# Altium schematic sheet DTU_PWR.SchDoc(DTU_PWR)
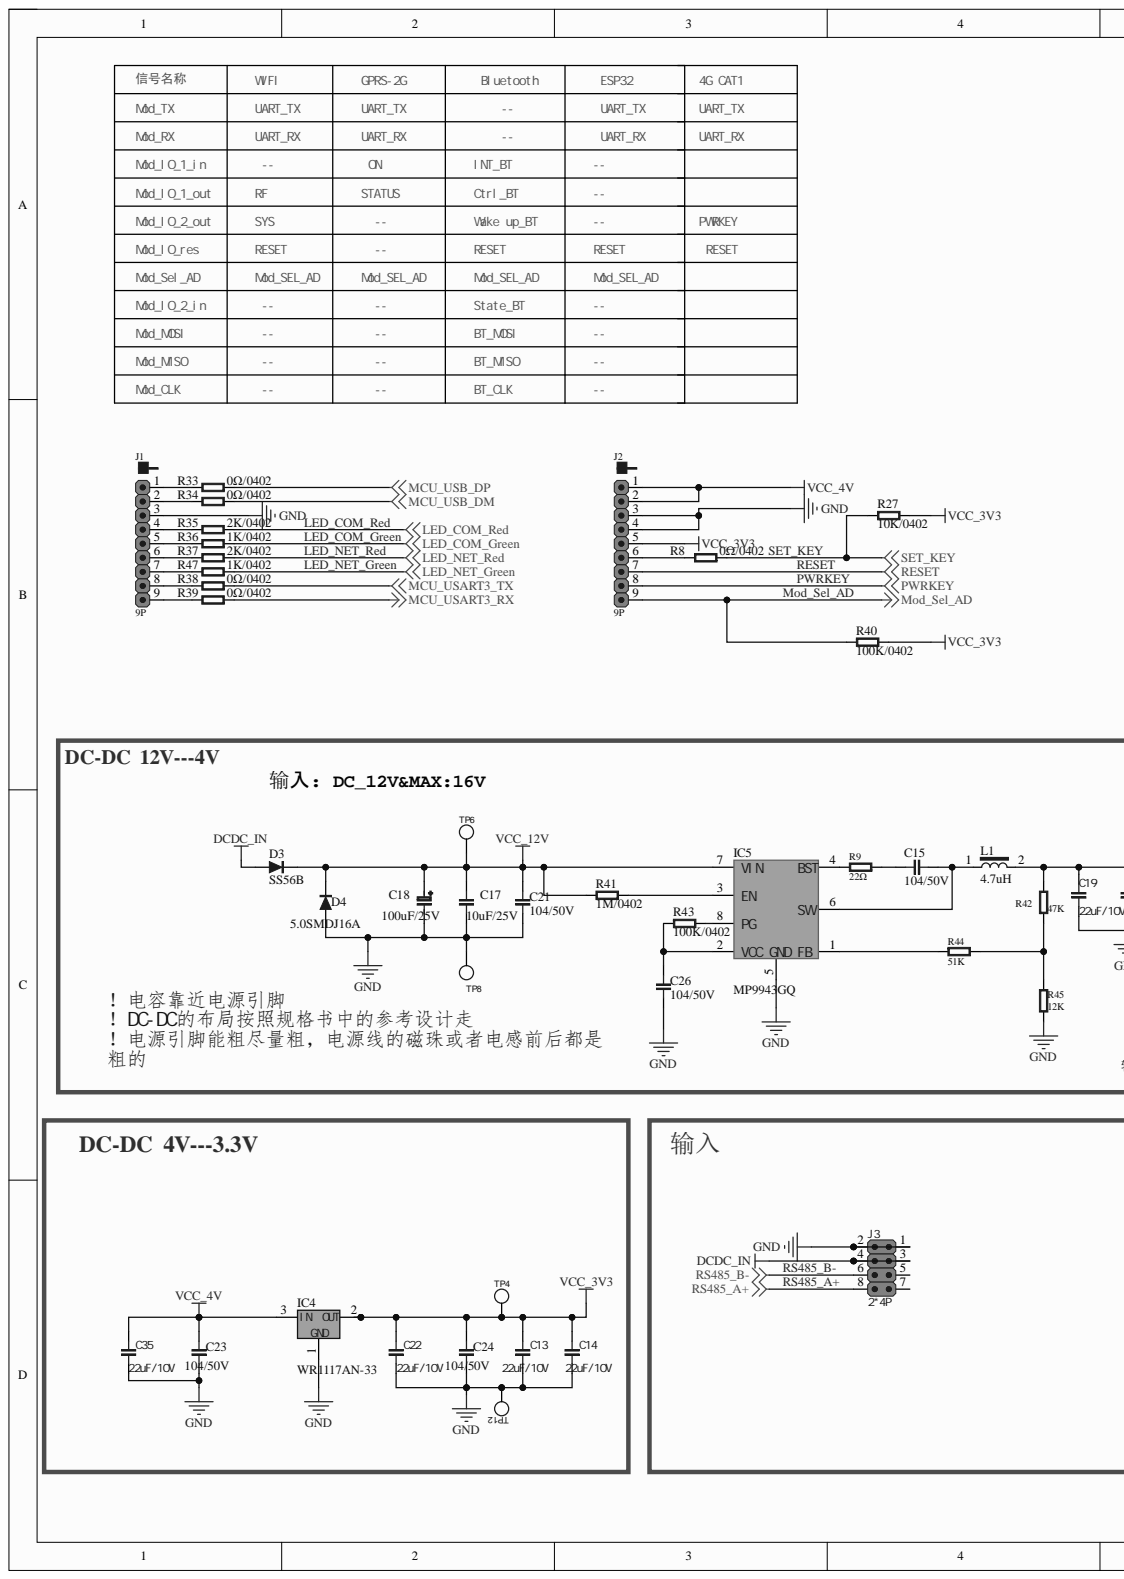
[diagram p0: DTU_PWR.SchDoc, left]
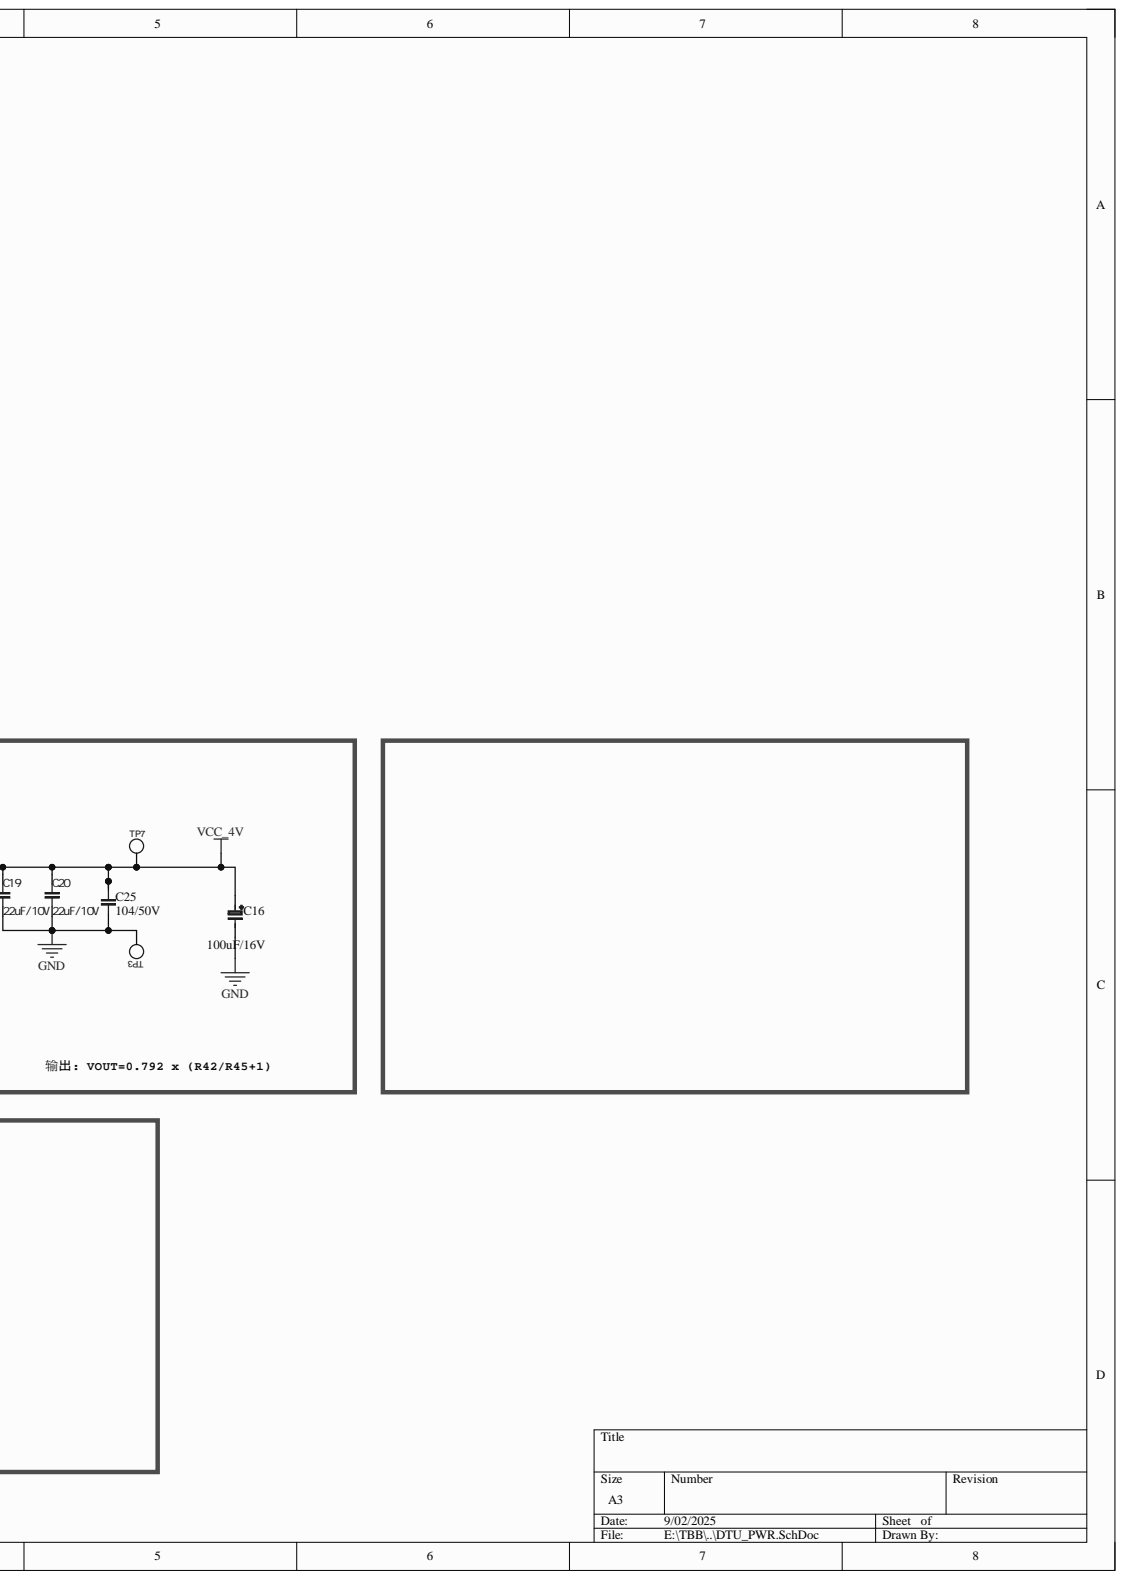
[diagram p1: DTU_PWR.SchDoc, right]

## Parts
C13  22uF/10V  [C0805]
C14  22uF/10V  [C0805]
C15  104/50V  [C0402]
C16  100uF/16V  [CDS-Φ6.3×5.7]
C17  10uF/25V  [C1206]
C18  100uF/25V  [CDS-Φ6.3×7.7]
C19  22uF/10V  [C0805]
C20  22uF/10V  [C0805]
C21  104/50V  [C0402]
C22  22uF/10V  [C0805]
C23  104/50V  [C0402]
C24  104/50V  [C0402]
C25  104/50V  [C0402]
C26  104/50V  [C0402]
C35  22uF/10V  [C0805]
D3  SS56B  [SMB]
D4  5.0SMDJ16A  [SMC]
IC4  WR1117AN-33  [SOT-223]
IC5  MP9943GQ  [QFN8-3.0X3.0-0.65]
J1  9P  [CN_1_9P_254_B]
J2  9P  [CN_1_9P_254_B]
J3  2*4P  [201R-2*4P-P2.0(2.7-4)]
L1  4.7uH  [7.0*7.0*5.5]
R8  0Ω/0402  [R0402]
R9  22Ω  [R0603]
R27  10K/0402  [R0402]
R33  0Ω/0402  [R0402]
R34  0Ω/0402  [R0402]
R35  2K/0402  [R0402]
R36  1K/0402  [R0402]
R37  2K/0402  [R0402]
R38  0Ω/0402  [R0402]
R39  0Ω/0402  [R0402]
R40  100K/0402  [R0402]
R41  1M/0402  [R0402]
R42  47K  [R0603]
R43  100K/0402  [R0402]
R44  51K  [R0603]
R45  12K  [R0603]
R47  1K/0402  [R0402]
TP3  T_POINT_R  [TP-SMD]  (value not drawn)  (no BOM)
TP4  T_POINT_R  [TP-SMD]  (value not drawn)  (no BOM)
TP6  T_POINT_R  [TP-SMD]  (value not drawn)  (no BOM)
TP7  T_POINT_R  [TP-SMD]  (value not drawn)  (no BOM)
TP8  T_POINT_R  [TP-SMD]  (value not drawn)  (no BOM)
TP12  T_POINT_R  [TP-SMD]  (value not drawn)  (no BOM)

## Nets
DCDC_IN: D3.1 J3.3 J3.4
GND: C13.2 C14.2 C16.2 C17.2 C18.2 C19.2 C20.2 C21.1 C22.2 C23.2 C24.2 C25.1 C26.1 C35.2 D4.1 IC4.1 IC5.5 J1.3 J2.3 J2.4 J3.1 J3.2 R45.1 TP3.1 TP8.1 TP12.1
MCU_USART3_RX: R39.2
MCU_USART3_TX: R38.2
MCU_USB_DM: R34.2
MCU_USB_DP: R33.2
VCC_3V3: C13.1 C14.1 C22.1 C24.1 IC4.2 J2.5 R27.1 R40.1 TP4.1
VCC_4V: C16.1 C19.1 C20.1 C23.1 C25.2 C35.1 IC4.3 J2.1 J2.2 L1.2 R42.1 TP7.1
VCC_12V: C17.1 C18.1 C21.2 D3.2 D4.2 IC5.7(VIN) R41.1 TP6.1
LED_COM_Green: R36.2
LED_COM_Red: R35.2
LED_NET_Green: R47.2
LED_NET_Red: R37.2
Mod_Sel_AD: J2.9 R40.2
PWRKEY: J2.8
RESET: J2.7
RS485_A+: J3.8
RS485_B-: J3.6
SET_KEY: R8.2 R27.2
NetC15_1: C15.1 IC5.6(SW) L1.1
NetC15_2: C15.2 R9.2
NetC26_2: C26.2 IC5.2(VCC) R43.2
NetIC5_1: IC5.1(FB) R44.2
NetIC5_3: IC5.3(EN) R41.2
NetIC5_4: IC5.4(BST) R9.1
NetIC5_8: IC5.8(PG) R43.1
NetJ1_1: J1.1 R33.1
NetJ1_2: J1.2 R34.1
NetJ1_4: J1.4 R35.1
NetJ1_5: J1.5 R36.1
NetJ1_6: J1.6 R37.1
NetJ1_7: J1.7 R47.1
NetJ1_8: J1.8 R38.1
NetJ1_9: J1.9 R39.1
NetJ2_6: J2.6 R8.1
NetR42_2: R42.2 R44.1 R45.2

Not connected: J3 5 7

## Library data of the parts
C13 C14 C19 C20 C22 C35 = C0805_22uF_10V: 贴片电容,0805,226,22uF,10V,±20%; Part_number: 10206-0094; Component Kind: Standard; Library Name: Capacity.SchLib
  C19 C20: 料号: 10206-0094
C15 C21 C23-C26 = C0402_100nF_50V: 贴片电容,X7R,0402,104,100nF,50V,±10%,-55℃～125℃; Part_number: 10206-0123; Component Kind: Standard; 料号: 10206-0123; Library Name: Capacity.SchLib
C16 = CDS-100uF/16V: 贴片电解电容,6.3*5.3mm,100uF,16V,±20%,-55℃～105℃; Part_number: 10206-0001; Component Kind: Standard; 料号: 10206-0001; Library Name: Capacity.SchLib
C17 = C1206_10uF_25V: 贴片电容,1206,X7R,106,25V,10uF,±10%,-55℃～125℃; Part_number: 10206-0063; Component Kind: Standard; Library Name: Capacity.SchLib
C18 = 100uF/25V: 贴片电解电容,VEJ101M1ETR-0607,100uF,25V,±20%,6.3*7.7mm; Part_number: 10206-0095; Component Kind: Standard; Library Name: Capacity.SchLib
D3 = SS56B: 贴片肖特基二极管,SS56B,SMB(DO-214AA),VRRM=60V,IR=1mA,VF=0.7V; Part_number: 10501-0042; Component Kind: Standard; Library Name: DIODE.IntLib
D4 = 5.0SMDJ16A: 贴片TVS管5.0SMDJ16A,SMC/DO-214AB,瞬态抑制二极管,VRWM=16V君耀; Part_number: 10501-0046; Component Kind: Standard; Library Name: DIODE.IntLib
IC4 = WR1117AN-33: 贴片LDO,WR1117AN-33,SOT-223,VO=3.3V,VINmax=13V,1A,-40~125℃; Part_number: 10802-0249; Component Kind: Standard; Library Name: IC.IntLib; 料号: 10802-0249
IC5 = MP9943GQ: 贴片IC,MP9943GQ,QFN-8,电源芯片,3A/36V,-40℃-125℃,MPS; Part_number: 10802-0241; Component Kind: Standard; 料号: 10802-0241; Library Name: MB-EMS.SCHLIB
J1 J2 = HEADER 9: 端子,单排母座,2.54*8.5-1*9P/180°/r/L9.8,Odia; Part_number: 10904-0093; Component Kind: Standard; Library Name: MianBoard.SCHLIB
J3 = 201R-2*4P-P2.0: 插针,双排,2.0*5.6*2*4P,Odia; Part_number: 10904-0094; Component Kind: Standard; Library Name: CONNECTOR.IntLib
L1 = SLF7055T-4R7N3R1-3PF: 贴片电感,SLF7055T-4R7N3R1-3PF,4.7uH,±30%,3.6A,28mΩ,7*7*5.5mm,-40～105℃,TDK,ROHS; Part_number: 10303-0013; Component Kind: Standard; Library Name: INDUCTANCE.IntLib; 料号: 10303-0013
R8 R33 R34 R38 R39 = R0402_0Ω: 贴片电阻,0402,0Ω,1/16W,±1%; Part_number: 10102-0253; Component Kind: Standard; Library Name: Resistance.SchLib
  R8: 料号: 10102-0253
R9 = R0603_22Ω: 贴片电阻,0603,22Ω,1/10W,±1%; Part_number: 10102-0029; Component Kind: Standard; 料号: 10102-0029; Library Name: Resistance.SchLib
R27 = R0402_10K: 贴片电阻,RTT02103JTH,0402,10K,5%,Ralec; Part_number: 10102-0279; Component Kind: Standard; 料号: 10102-0279; Library Name: Resistance.SchLib
R35 R37 = R0402_2K: 贴片电阻,0402,2KΩ,1/16W,1%; Part_number: 10102-0309; Component Kind: Standard; Library Name: Resistance.SchLib
R36 R47 = R0402_1K: 贴片电阻,0402,1KΩ,1/16W,1%; Part_number: 10102-0276; Component Kind: Standard; Library Name: Resistance.SchLib
R40 R43 = R0402_100K: 贴片电阻,0402,100KΩ,1/16W,1%; Part_number: 10102-0310; Component Kind: Standard; Library Name: Resistance.SchLib
  R43: 料号: 10102-0310
R41 = R0402_1M: 贴片电阻,0402,1MΩ,1/16W,5%; Part_number: 10102-0281; Component Kind: Standard; Ibis Model: Model Name; Signal Integrity: Model Name; 料号: 10102-0281; Library Name: Resistance.SchLib
R42 = R0603: 贴片电阻,0603,47KΩ,1/10W,±1%; Part_number: 10102-0060; Component Kind: Standard; Library Name: RESISTOR.IntLib
R44 = R0603: 贴片电阻,0603,51KΩ,1/10W,±1%; Part_number: 10102-0067; Component Kind: Standard; Library Name: RESISTOR.IntLib
R45 = R0603_12K: 贴片电阻,0603,12KΩ,1/10W,±1%; Part_number: 10102-0011; Component Kind: Standard; 料号: 10102-0011; Library Name: Resistance.SchLib
